# Altium schematic sheet Sheet1.SchDoc(Sheet1)
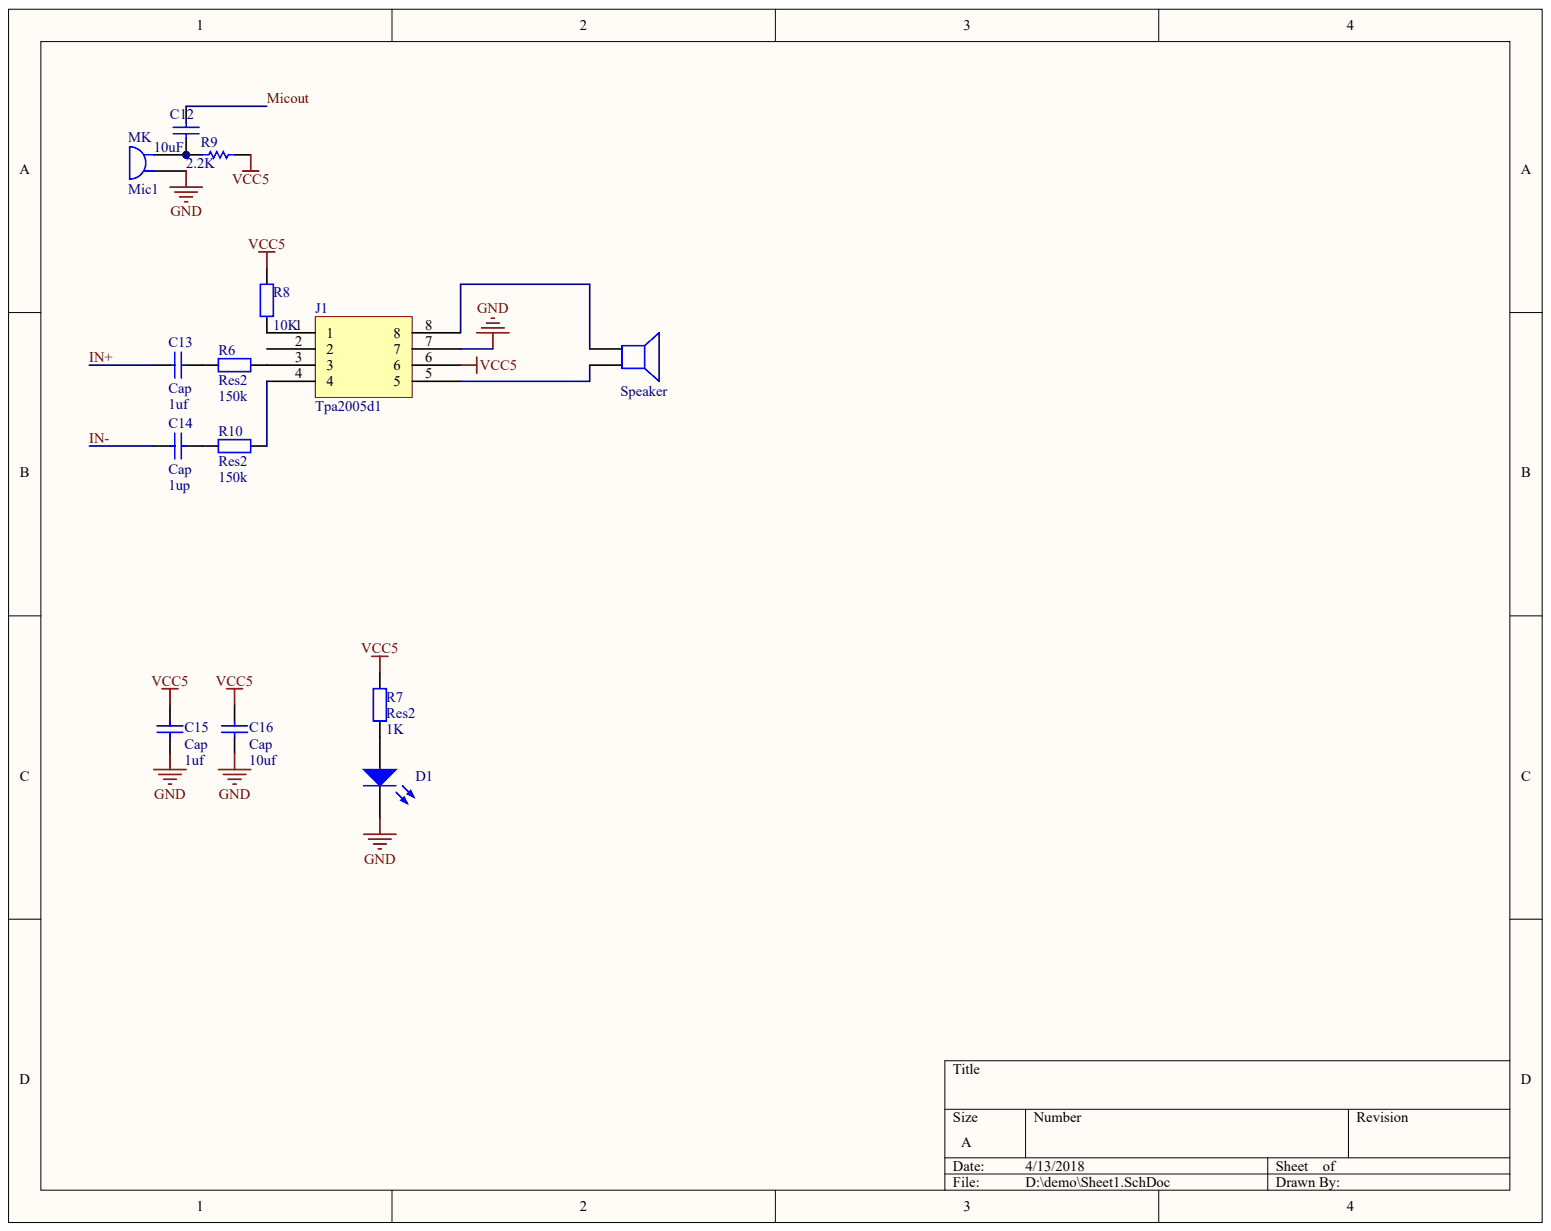
[diagram p0: Sheet1.SchDoc]

## Parts
C12  value 10uF  (hidden comment: Cap)
C13  Cap  value 1uf
C14  Cap  value 1up
C15  Cap  value 1uf
C16  Cap  value 10uf
D1  LED1  (value not drawn)
J1  Tpa2005d1
LS  Speaker
MK  Mic1
R6  Res2  value 150k
R7  Res2  value 1K
R8  value 10K  (hidden comment: Res2)
R9  value 2.2K  (hidden comment: Res1)
R10  Res2  value 150k

## Nets
GND: C15.1 C16.1 D1.2 J1.7 MK.1
VCC5: C15.2 C16.2 J1.6 R7.2 R8.2 R9.2
IN+: C13.1
IN-: C14.1
MICOUT: C12.1
NetC12_2: C12.2 MK.2 R9.1
NetC13_2: C13.2 R6.1
NetC14_2: C14.2 R10.1
NetD1_1: D1.1 R7.1
NetJ1_1: J1.1 R8.1
NetJ1_3: J1.3 R6.2
NetJ1_4: J1.4 R10.2
NetJ1_5: J1.5 LS.2
NetJ1_8: J1.8 LS.1

Not connected: J1 2

## Library data of the parts
C13 = (no symbol name): Value: 1uf; Published: 8-Jun-2000; LatestRevisionDate: 17-Jul-2002; LatestRevisionNote: Re-released for DXP Platform.; PackageReference: RAD-0.3; PackageDescription: Radial Cap, Thru-Hole; 2 Leads; 0.3 in Pin Spacing; Publisher: Altium Limited
C12 C14-C16 D1 J1 LS MK R6-R10 = (no symbol name)
  C12 C14-C16: Published: 8-Jun-2000; LatestRevisionDate: 17-Jul-2002; LatestRevisionNote: Re-released for DXP Platform.; PackageReference: RAD-0.3; PackageDescription: Radial Cap, Thru-Hole; 2 Leads; 0.3 in Pin Spacing
  D1: Published: 8-Jun-2000; LatestRevisionDate: 17-Dec-2003; LatestRevisionNote: PCB Footprint replaced.; DatasheetVersion: 16-Apr-2002; PackageVersion: 16-Apr-2002; PackageReference: 3.5X2.8X1.9; PackageDescription: SM LED; 2 C-Bend Leads; Body 3.5 x 2.8 x 1.9 mm, inc leads (LxWxH typ)
  LS MK: Published: 24-Mar-1999; LatestRevisionDate: 17-Jul-2002; LatestRevisionNote: Re-released for DXP Platform.; PackageReference: PIN2
  R6-R8 R10: Published: 8-Jun-2000; LatestRevisionDate: 17-Jul-2002; LatestRevisionNote: Re-released for DXP Platform.; PackageReference: AXIAL-0.4; PackageDescription: Axial Device, Thru-Hole; 2 Leads; 0.4 in Pin Spacing
  R9: Published: 8-Jun-2000; LatestRevisionDate: 17-Jul-2002; LatestRevisionNote: Re-released for DXP Platform.; PackageReference: AXIAL-0.3; PackageDescription: Axial Device, Thru-Hole; 2 Leads; 0.3 in Pin Spacing
  C12 C14-C16 D1 LS MK R6-R10: Publisher: Altium Limited
